# Altium schematic sheet TopLevel.SchDoc(TopLevel)
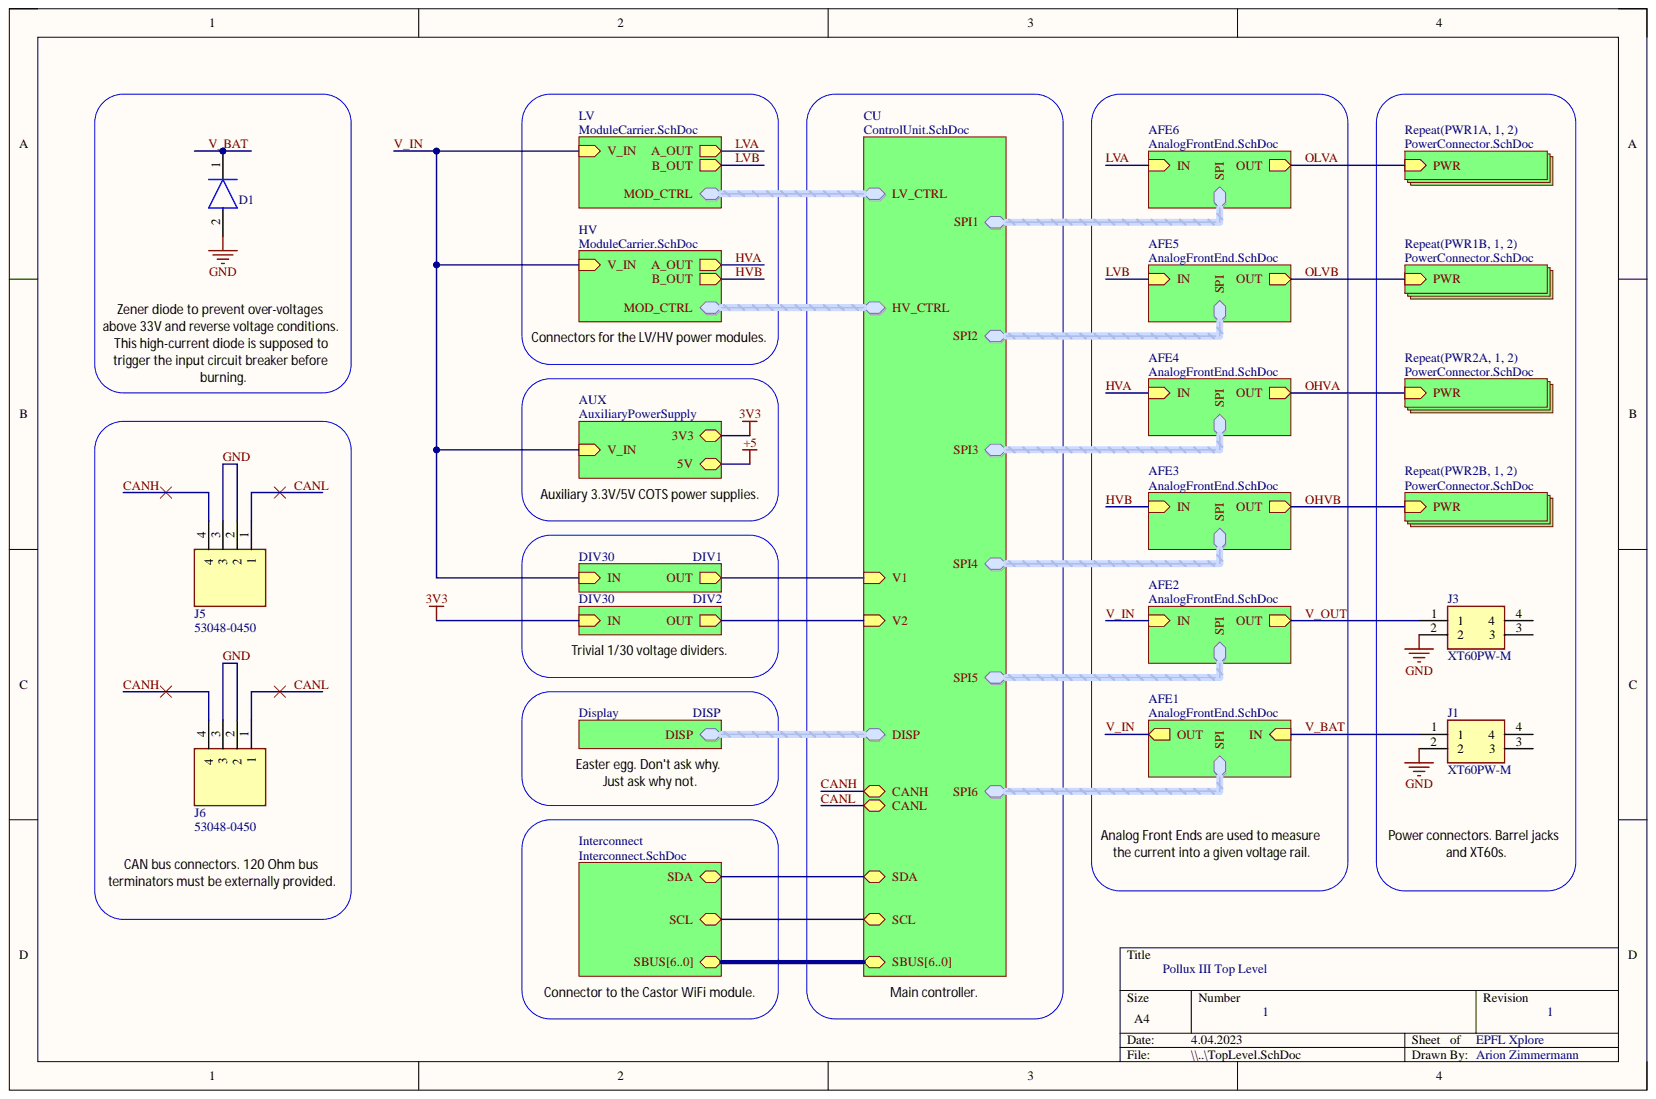
[diagram p0: TopLevel.SchDoc]

## Parts
D1  BZG03C33-HM3-08  [DIOM5127X229N]  (value not drawn)
J1  XT60PW-M  [XT60PWM]
J3  XT60PW-M  [XT60PWM]
J5  53048-0450  [SHDRRA4W35P0X125_1X4_675X550X350P]
J6  53048-0450  [SHDRRA4W35P0X125_1X4_675X550X350P]

## Nets
CANH: J5.4 J6.4
CANL: J5.1 J6.1
GND: D1.2 J1.2 J3.2 J5.2 J5.3 J6.2 J6.3
V_BAT: D1.1 J1.1
V_OUT: J3.1
NetJ1_3: J1.3
NetJ1_4: J1.4
NetJ3_3: J3.3
NetJ3_4: J3.4
3V3:
+5:
HVA:
HVB:
LVA:
LVB:
N00002_0:
N00002_1:
N00002_2:
N00002_3:
N00002_4:
N00002_5:
N00002_6:
N00004:
N00005:
N00006:
N00007:
N00008:
N00009:
N00010:
N00011:
N00012:
N00013:
N00014:
N00015:
N00016:
N00017:
N00018:
N00019:
N00020:
N00022:
N00024:
N00025:
N00026:
N00027:
N00028:
N00029:
N00030:
N00031:
N00032:
N00033:
N00034:
N00035:
N00036:
N00037:
N00038:
N00039:
N00040:
N00041:
N00042:
N00044:
N00046:
N00048:
N00050:
N00052:
N00058:
N00059:
N00060:
N00061:
N00062:
N00063:
N00064:
N00065:
OHVA:
OHVB:
OLVA:
OLVB:
V_IN:

## Library data of the parts
D1 = BZG03C33-HM3-08: Diode; Manufacturer_Name: Vishay; Arrow Part Number: BZG03C33-HM3-08; Arrow Price/Stock: https://www.arrow.com/en/products/bzg03c33-hm3-08/vishay; Component Kind: Standard; Datasheet Link: https://www.arrow.com/en/products/bzg03c33-hm3-08/vishay; Height: 2.29mm; Library Name: CarrierBoard.SCHLIB; Manufacturer_Part_Number: BZG03C33-HM3-08; Mouser Part Number: 78-BZG03C33-HM3-08; Mouser Price/Stock: https://www.mouser.co.uk/ProductDetail/Vishay-Semiconductors/BZG03C33-HM3-08?qs=j%252B1pi9TdxUbhV%2FXINkfeTw%3D%3D
J1 J3 = XT60PW-M: Connector; Manufacturer_Name: Changzou Amass Elec; Component Kind: Standard; Datasheet Link: https://www.tme.eu/Document/9b8d0c5eb7094295f3d3112c214d3ade/XT60PW%20SPEC.pdf; Height: 8.4mm; Library Name: SamacSys.SchLib; Manufacturer_Part_Number: XT60PW-M
J5 J6 = 53048-0450: Connector; Manufacturer_Name: Molex; Component Kind: Standard; Datasheet Link: https://www.molex.com/pdm_docs/sd/530480450_sd.pdf; Height: 3.5mm; Manufacturer_Part_Number: 53048-0450; Mouser Part Number: 538-53048-0450; Mouser Price/Stock: https://www.mouser.co.uk/ProductDetail/Molex/53048-0450?qs=0lSvoLzn4L%252Bhzo05ywM78Q%3D%3D
